# Altium schematic sheet [SCH]_MainProcessor.SchDoc
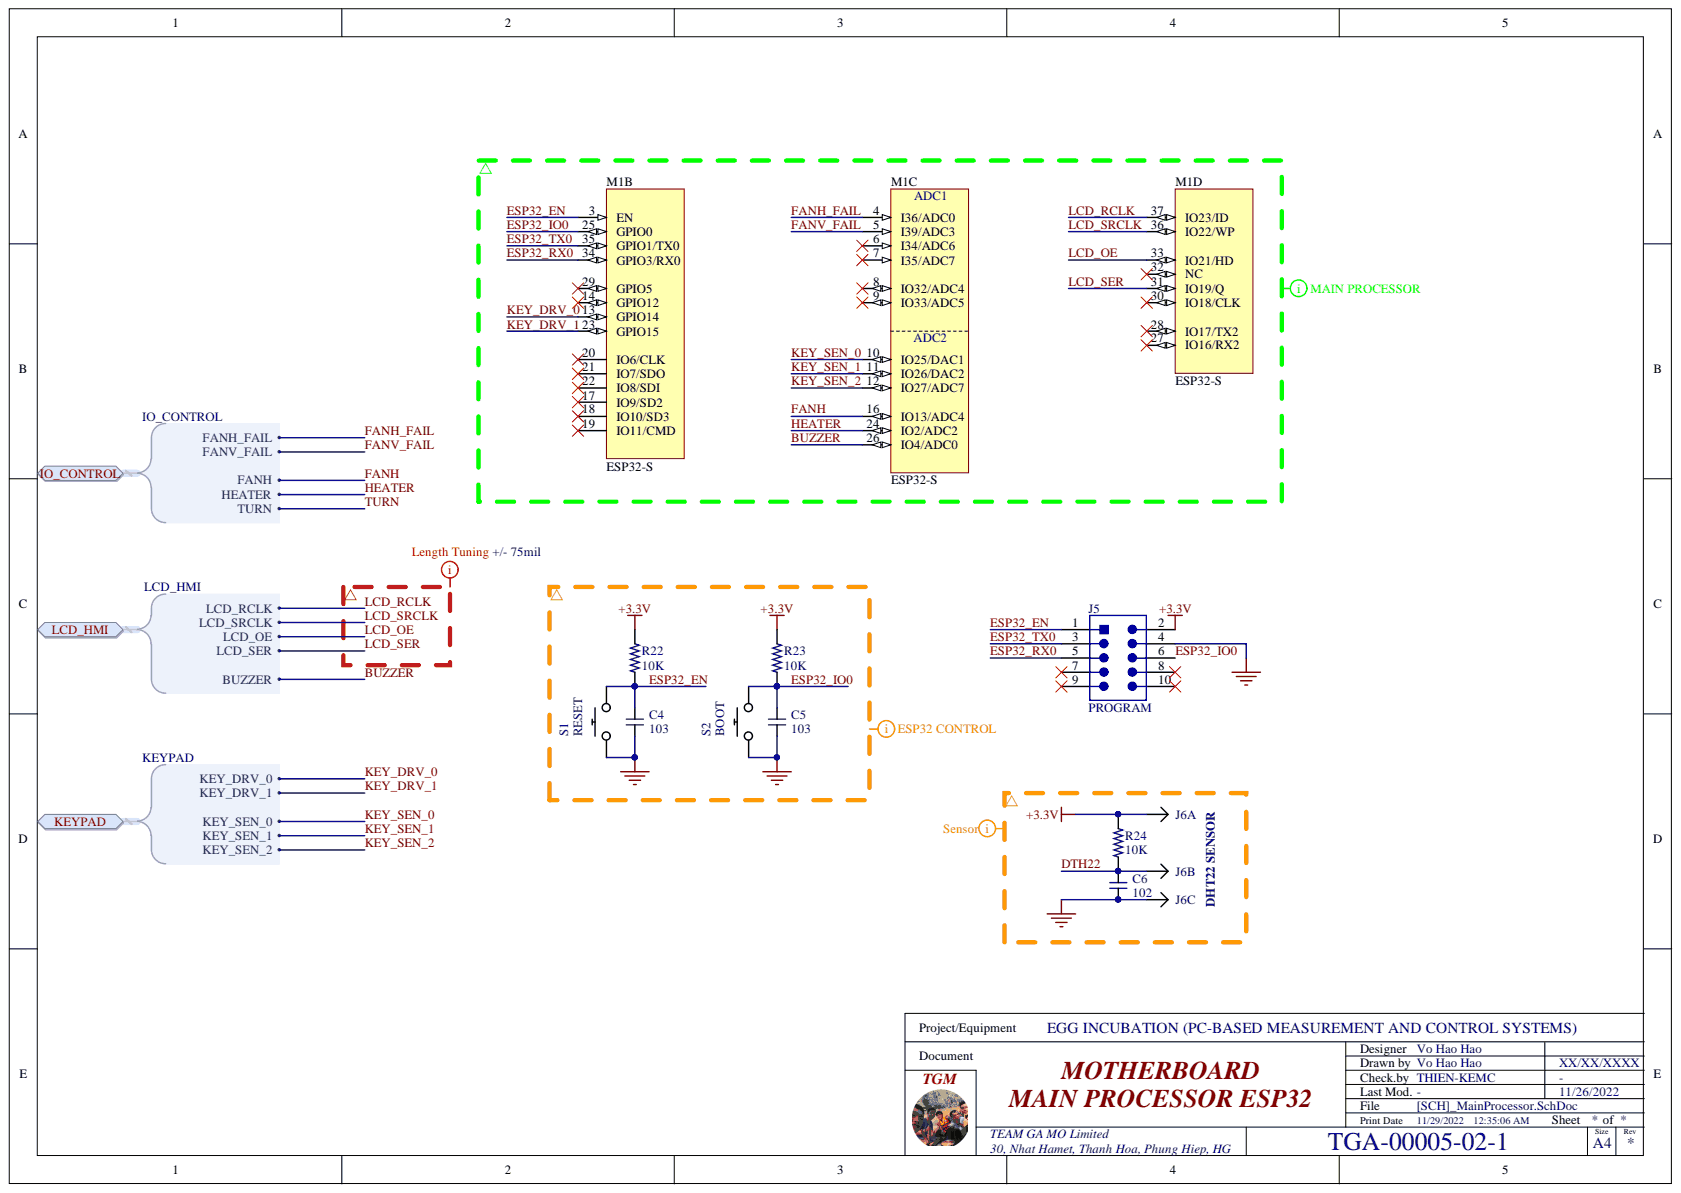
[diagram p0: [SCH]_MainProcessor.SchDoc]

## Parts
C4  103  [CAP-SMD-0805]
C5  103  [CAP-SMD-0805]
C6  102  [CAP-SMD-0805]
J5  PROGRAM  [IDC10]
J6  DHT22  [KF3/5.08]  (parts A,B,C)
M1  ESP32-S  [ESP32-S-Footprint]  (parts B,C,D)
R22  value 10K  [RES-SMD-0805]  (hidden comment: R0805)
R23  value 10K  [RES-SMD-0805]  (hidden comment: R0805)
R24  value 10K  [RES-SMD-0805]  (hidden comment: R0805)
S1  RESET  [TL3342F260QG]
S2  BOOT  [TL3342F260QG]

## Ports
IO_CONTROL, IO_CONTROL.FANH, IO_CONTROL.FANH_FAIL, IO_CONTROL.FANV_FAIL, IO_CONTROL.HEATER, IO_CONTROL.TURN, KEYPAD, KEYPAD.KEY_DRV_0, KEYPAD.KEY_DRV_1, KEYPAD.KEY_SEN_0, KEYPAD.KEY_SEN_1, KEYPAD.KEY_SEN_2, LCD_HMI, LCD_HMI.BUZZER, LCD_HMI.LCD_OE, LCD_HMI.LCD_RCLK, LCD_HMI.LCD_SER, LCD_HMI.LCD_SRCLK

## Nets
+3.3V: J5.2 J6.1 R22.2 R23.2 R24.2
GND: C4.1 C5.1 C6.1 J5.4 J6.3 S1.1 S2.1
BUZZER: M1.26(IO4/ADC0) ; port LCD_HMI
DTH22: C6.2 J6.2 R24.1
ESP32_EN: C4.2 J5.1 M1.3(EN) R22.1 S1.2
ESP32_IO0: C5.2 J5.6 M1.25(GPIO0) R23.1 S2.2
ESP32_RX0: J5.5 M1.34(GPIO3/RX0)
ESP32_TX0: J5.3 M1.35(GPIO1/TX0)
FANH: M1.16(IO13/ADC4) ; port IO_CONTROL
FANH_FAIL: M1.4(I36/ADC0) ; port IO_CONTROL
FANV_FAIL: M1.5(I39/ADC3) ; port IO_CONTROL
HEATER: M1.24(IO2/ADC2) ; port IO_CONTROL
KEY_DRV_0: M1.13(GPIO14) ; port KEYPAD
KEY_DRV_1: M1.23(GPIO15) ; port KEYPAD
KEY_SEN_0: M1.10(IO25/DAC1) ; port KEYPAD
KEY_SEN_1: M1.11(IO26/DAC2) ; port KEYPAD
KEY_SEN_2: M1.12(IO27/ADC7) ; port KEYPAD
LCD_OE: M1.33(IO21/HD) ; port LCD_HMI
LCD_RCLK: M1.37(IO23/ID) ; port LCD_HMI
LCD_SER: M1.31(IO19/Q) ; port LCD_HMI
LCD_SRCLK: M1.36(IO22/WP) ; port LCD_HMI
N00051:
N00052:
N00053:
N00054:
TURN: ; port IO_CONTROL

Not connected: J5 7 8 9 10; M1 6(I34/ADC6) 7(I35/ADC7) 8(IO32/ADC4) 9(IO33/ADC5) 14(GPIO12) 17(IO9/SD2) 18(IO10/SD3) 19(IO11/CMD) 20(IO6/CLK) 21(IO7/SDO) 22(IO8/SDI) 27(IO16/RX2) 28(IO17/TX2) 29(GPIO5) 30(IO18/CLK) 32(NC)

## Library data of the parts
C4-C6 = Cap-Non-SMD: Non-polar capacitor; Component Kind: Standard; Library Name: TOAN_VERSION_1_0.IntLib
J5 = IDE_5X2: Male Box Header WR-BHD, THT, Vertical, pitch 2.54 mm, 10 pins; Component Kind: Standard; Library Name: TOAN_VERSION_1_0.IntLib
J6 = JP3: Component Kind: Standard; Library Name: TOAN_VERSION_1_0.IntLib
M1 = ESP32-S: Tensilica Xtensa LX6 microprocessor @ 160 or 240 MHz · 320 KiB SRAM; Bandwidth: 72 MHz; CPU Name: ESP32-D0WDQ6; Data Rate: 150 Mbps; Interface: Ethernet, I2C, I2S, SPI, UART; Max CPU Freq: 240 MHz; Nominal Supply Current: 500 mA; Number of ADC Channels: 16; Number of cores: 2; Number of GPIO: 32; Supply Voltage: 2.7 ~ 3.6 V; Component Kind: Standard; ComponentLink1Description: PCB; ComponentLink1URL: https://evertdekker.com/wp/?p=854; Height: 3.2 mm; Temperature Range: -40 to +85 degC; Library Name: TOAN_VERSION_1_0.IntLib
R22-R24 = RES: Chip Resistor, 0805, 1%, 1/8 W; Component Kind: Standard; PackageDescription: Chip Resistor; PackageReference: J1-0805; Price: 0.005; Simulation: RESISTOR; Supplier Part Number 1: 311-1.00KLRCT-ND; Library Name: TOAN_VERSION_1_0.IntLib
S1 S2 = SWITCH TACTILE SPST: SWITCH TACTILE SPST-NO 0.05A 12V; Component Kind: Standard; Manufacturer Part Number: TL3342F260QG; Price: 0.25; Supplier 1: Digi-Key; Supplier Part Number 1: EG5470CT-ND; Library Name: TOAN_VERSION_1_0.IntLib
